# Altium schematic sheet C1804 FreqCounter - REF Clock & Freq (REV04).SchDoc
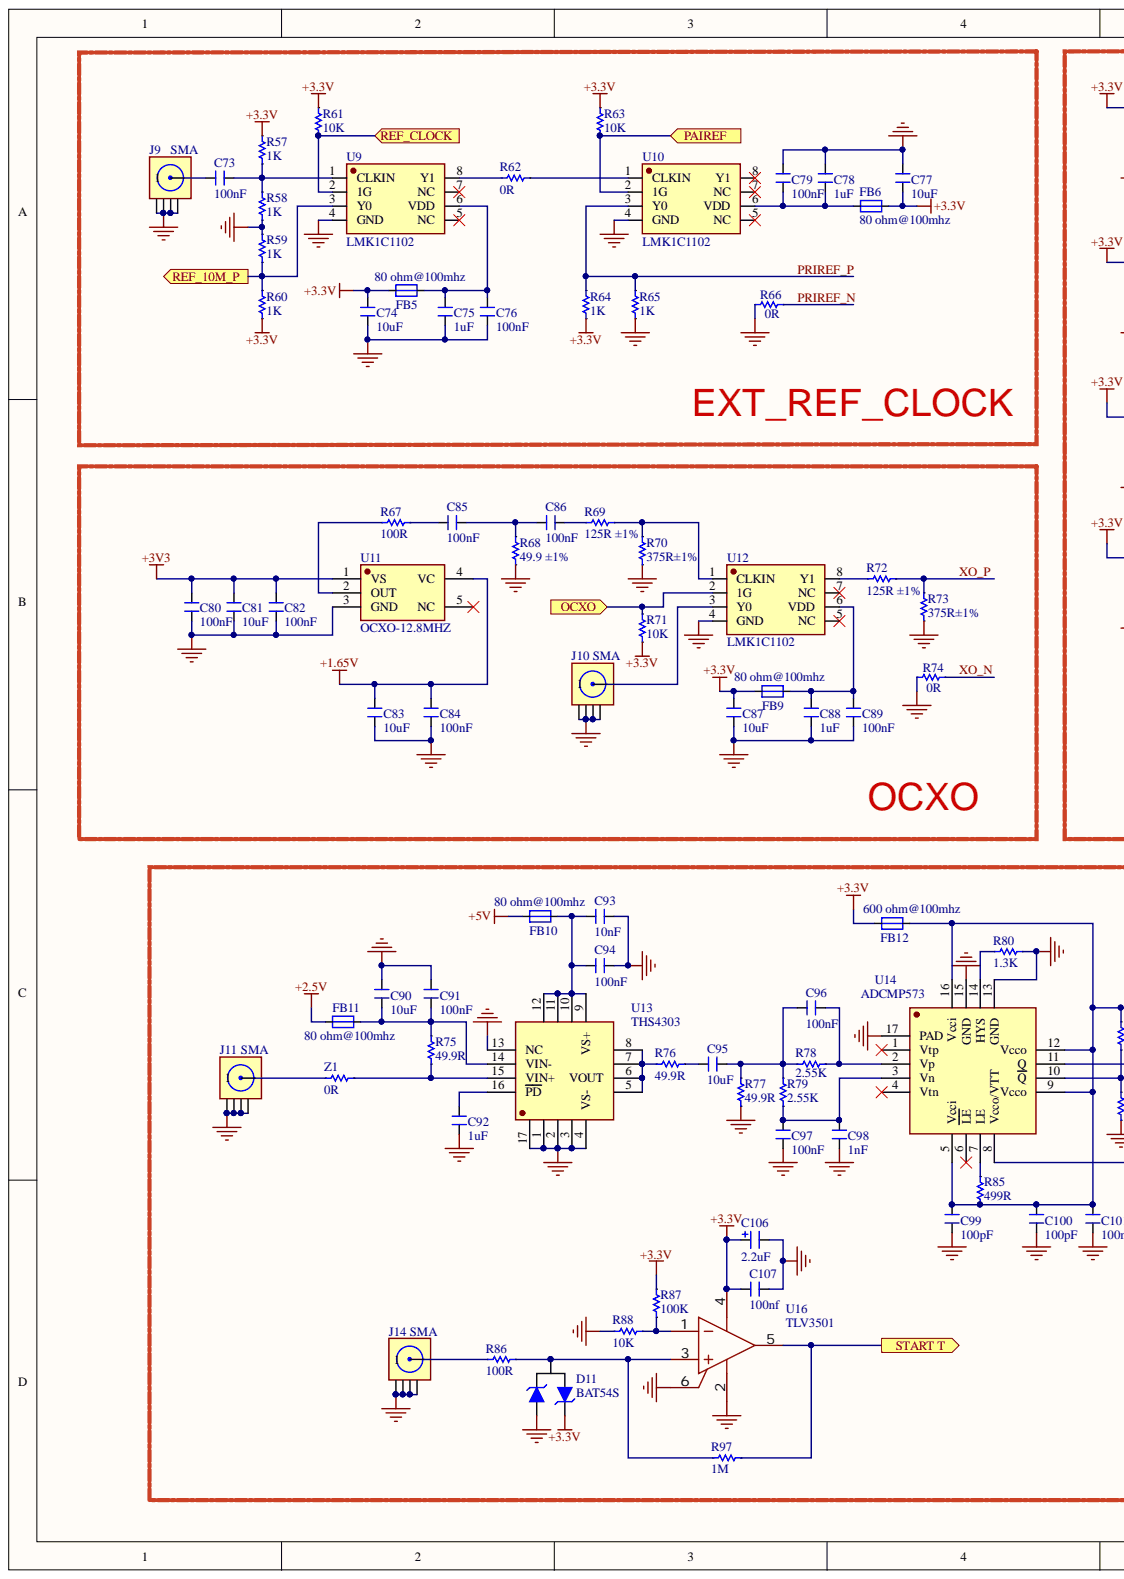
[diagram p0: C1804 FreqCounter - REF Clock & Freq (REV04).SchDoc, left]
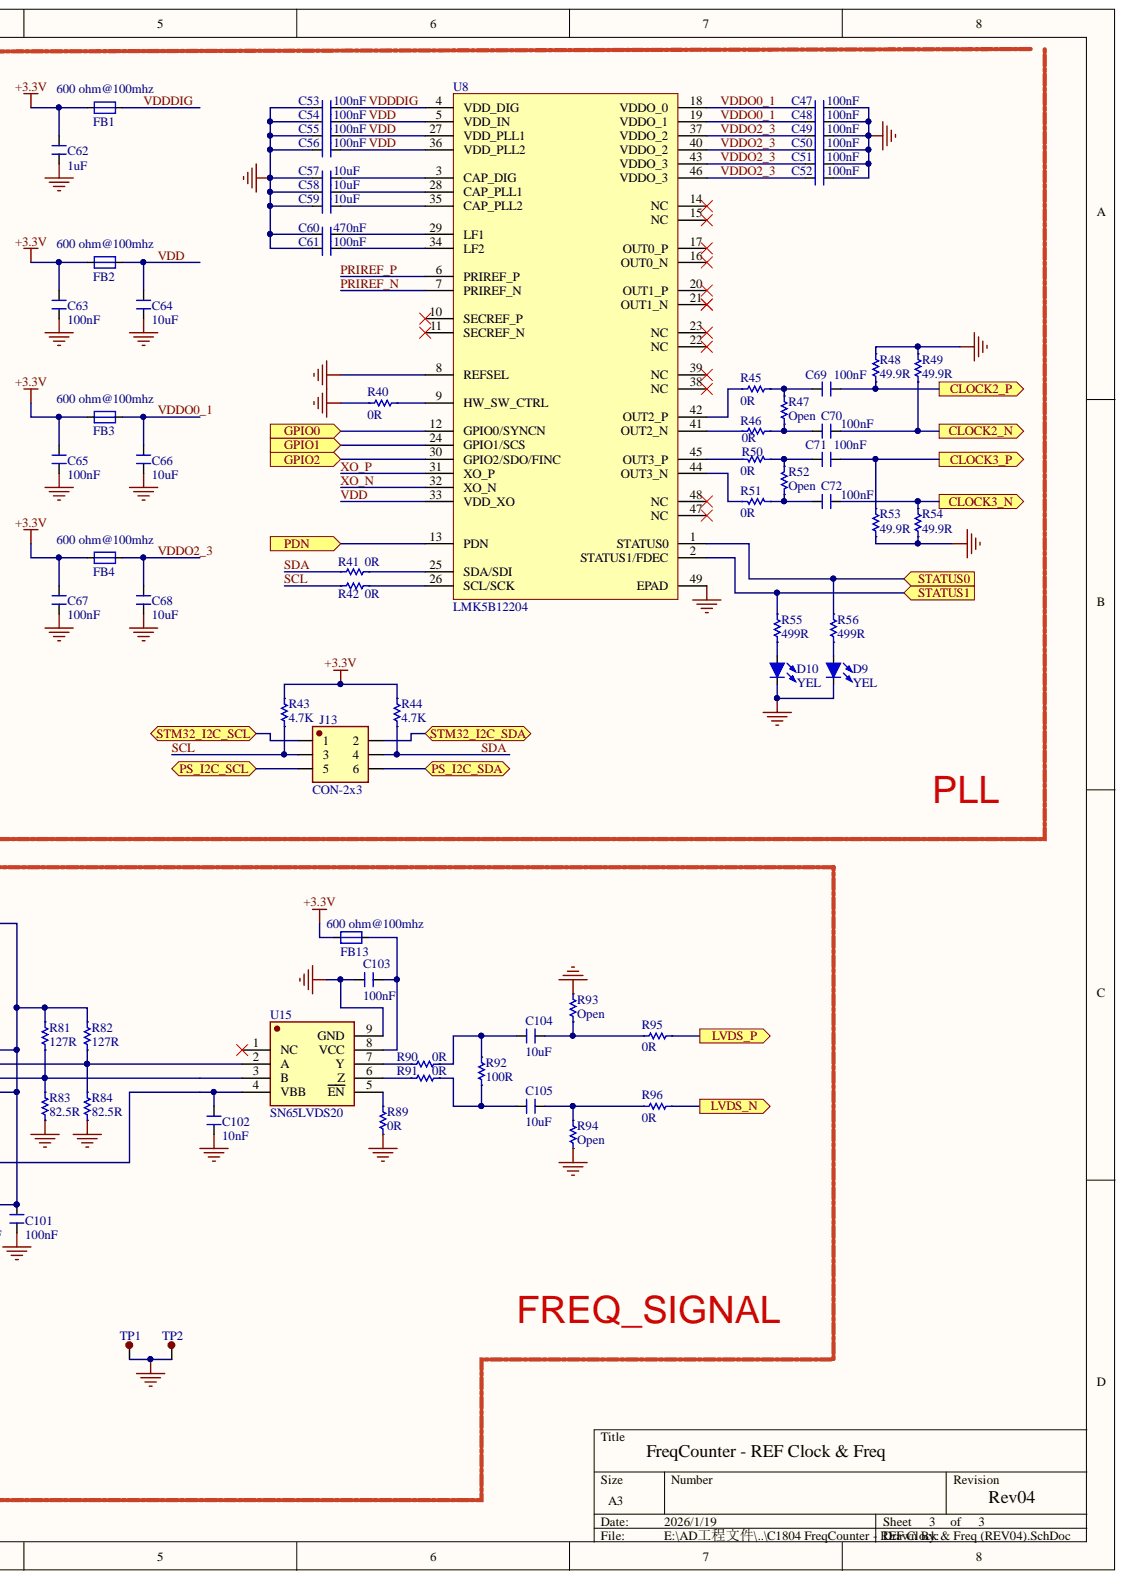
[diagram p1: C1804 FreqCounter - REF Clock & Freq (REV04).SchDoc, right]

## Parts
C47  100nF  [C-0603]
C48  100nF  [C-0603]
C49  100nF  [C-0402]
C50  100nF  [C-0402]
C51  100nF  [C-0402]
C52  100nF  [C-0402]
C53  100nF  [C-0603]
C54  100nF  [C-0603]
C55  100nF  [C-0603]
C56  100nF  [C-0603]
C57  10uF  [C-0603]
C58  10uF  [C-0603]
C59  10uF  [C-0603]
C60  470nF  [C-0603]
C61  100nF  [C-0603]
C62  1uF  [C-0603]
C63  100nF  [C-0603]
C64  10uF  [C-0603]
C65  100nF  [C-0603]
C66  10uF  [C-0603]
C67  100nF  [C-0603]
C68  10uF  [C-0402]
C69  100nF  [C-0603]
C70  100nF  [C-0603]
C71  100nF  [C-0603]
C72  100nF  [C-0603]
C73  100nF  [C-0603]
C74  10uF  [C-0603]
C75  1uF  [C-0603]
C76  100nF  [C-0603]
C77  10uF  [C-0603]
C78  1uF  [C-0603]
C79  100nF  [C-0603]
C80  100nF  [C-0603]
C81  10uF  [C-0805]
C82  100nF  [C-0603]
C83  10uF  [C-0805]
C84  100nF  [C-0603]
C85  100nF  [C-0603]
C86  100nF  [C-0603]
C87  10uF  [C-0603]
C88  1uF  [C-0603]
C89  100nF  [C-0603]
C90  10uF  [C-0603]
C91  100nF  [C-0603]
C92  1uF  [C-0603]
C93  10nF  [C-0603]
C94  100nF  [C-0603]
C95  10uF  [C-0603]
C96  100nF  [C-0603]
C97  100nF  [C-0603]
C98  1nF  [C-0603]
C99  100pF  [C-0603]
C100  100pF  [C-0603]
C101  100nF  [C-0603]
C102  10nF  [C-0603]
C103  100nF  [C-0603]
C104  10uF  [C-0603]
C105  10uF  [C-0603]
C106  2.2uF  [CASE-A (3216)]
C107  100nf  [C-0603]
D9  YEL  [LED-0805]
D10  YEL  [LED-0805]
D11  BAT54S  [SOT-23 (1,2,3)]
FB1  600 ohm@100mhz  [R-0603]
FB2  600 ohm@100mhz  [R-0603]
FB3  600 ohm@100mhz  [R-0603]
FB4  600 ohm@100mhz  [R-0603]
FB5  80 ohm@100mhz  [R-0805]
FB6  80 ohm@100mhz  [R-0805]
FB9  80 ohm@100mhz  [R-0805]
FB10  80 ohm@100mhz  [R-0805]
FB11  80 ohm@100mhz  [R-0805]
FB12  600 ohm@100mhz  [R-0603]
FB13  600 ohm@100mhz  [R-0603]
J9  SMA  [SMA-90DEG]
J10  SMA  [SMA-90DEG]
J11  SMA  [SMA-90DEG]
J13  CON-2x3  [DIP-2x3 (P=2.54MM)]
J14  SMA  [SMA-90DEG]
R40  0R  [R-0603]
R41  0R  [R-0603]
R42  0R  [R-0603]
R43  4.7K  [R-0603]
R44  4.7K  [R-0603]
R45  0R  [R-0603]
R46  0R  [R-0603]
R47  Open  [R-0603]
R48  49.9R  [R-0603]
R49  49.9R  [R-0603]
R50  0R  [R-0603]
R51  0R  [R-0603]
R52  Open  [R-0603]
R53  49.9R  [R-0603]
R54  49.9R  [R-0603]
R55  499R  [R-0603]
R56  499R  [R-0603]
R57  1K  [R-0603]
R58  1K  [R-0603]
R59  1K  [R-0603]
R60  1K  [R-0603]
R61  10K  [R-0603]
R62  0R  [R-0603]
R63  10K  [R-0603]
R64  1K  [R-0603]
R65  1K  [R-0603]
R66  0R  [R-0603]
R67  100R  [R-0603]
R68  49.9 ±1%  [R-0603]
R69  125R ±1%  [R-0603]
R70  375R±1%  [R-0603]
R71  10K  [R-0603]
R72  125R ±1%  [R-0603]
R73  375R±1%  [R-0603]
R74  0R  [R-0603]
R75  49.9R  [R-0603]
R76  49.9R  [R-0603]
R77  49.9R  [R-0603]
R78  2.55K  [R-0603]
R79  2.55K  [R-0603]
R80  1.3K  [R-0603]
R81  127R  [R-0603]
R82  127R  [R-0603]
R83  82.5R  [R-0603]
R84  82.5R  [R-0603]
R85  499R  [R-0603]
R86  100R  [R-0603]
R87  100K  [R-0603]
R88  10K  [R-0603]
R89  0R  [R-0603]
R90  0R  [R-0603]
R91  0R  [R-0603]
R92  100R  [R-0603]
R93  Open  [R-0603]
R94  Open  [R-0603]
R95  0R  [R-0603]
R96  0R  [R-0603]
R97  1M  [R-0603]
TP1  Test Point  [Test point]  (value not drawn)
TP2  Test Point  [Test point]  (value not drawn)
U8  LMK5B12204  [VQFN-48 (7*7,P=0.5mm)]
U9  LMK1C1102  [WSON-8 (2*2,P=0.5MM)]
U10  LMK1C1102  [WSON-8 (2*2,P=0.5MM)]
U11  OCXO-12.8MHZ  [OCXO-5 (20x20mm)]
U12  LMK1C1102  [WSON-8 (2*2,P=0.5MM)]
U13  THS4303  [QFN-16 (P=0.5mm)]
U14  ADCMP573  [QFN-16 (P=0.5mm)]
U15  SN65LVDS20  [WSON-8-EP (2*2,P=0.5MM)]
U16  TLV3501  [SOT23-6]
Z1  0R  [R-0603]

## Ports
CLOCK2_N, CLOCK2_P, CLOCK3_N, CLOCK3_P, GPIO0, GPIO1, GPIO2, LVDS_N, LVDS_P, OCXO, PAIREF, PDN, PS_I2C_SCL, PS_I2C_SDA, REF_10M_P, REF_CLOCK, START T, STATUS0, STATUS1, STM32_I2C_SCL, STM32_I2C_SDA

## Nets
+1.65V: C83.1 C84.1 U11.4(VC)
+2.5V: FB11.1
+3.3V: C62.1 C63.1 C65.1 C67.1 C74.2 C77.2 C87.2 C106.1 C107.1 D11.2 FB1.1 FB2.1 FB3.1 FB4.1 FB5.1 FB6.1 FB9.1 FB12.1 FB13.2 R43.1 R44.1 R57.2 R60.1 R61.2 R63.2 R64.2 R71.1 R87.2 U16.4
+3V3: C80.1 C81.1 C82.1 U11.1(VS)
+5V: FB10.1
GND: C47.2 C48.2 C49.2 C50.2 C51.2 C52.2 C53.1 C54.1 C55.1 C56.1 C57.1 C58.1 C59.1 C60.1 C61.1 C62.2 C63.2 C64.2 C65.2 C66.2 C67.2 C68.2 C74.1 C75.2 C76.2 C77.1 C78.2 C79.2 C80.2 C81.2 C82.2 C83.2 C84.2 C87.1 C88.2 C89.2 C90.1 C91.1 C92.1 C93.2 C94.2 C97.2 C98.2 C99.2 C100.2 C101.2 C102.2 C103.2 C106.2 C107.2 D9.K D10.K D11.1 J9.2 J9.3 J9.4 J9.5 J10.2 J10.3 J10.4 J10.5 J11.2 J11.3 J11.4 J11.5 J14.2 J14.3 J14.4 J14.5 R40.2 R48.2 R49.2 R53.1 R54.1 R58.1 R59.2 R65.1 R66.1 R68.2 R70.2 R73.2 R74.1 R77.1 R80.2 R83.1 R84.1 R88.1 R89.2 R93.2 R94.1 TP1.1 TP2.1 U8.8(REFSEL) U8.49(EPAD) U9.4(GND) U10.4(GND) U11.3(GND) U12.4(GND) U13.1 U13.2 U13.3 U13.4(VS-) U13.13(NC) U13.17 U14.13(GND) U14.15(GND) U14.17(PAD) U15.9(GND) U16.2 U16.6
CLOCK2_N: C70.1 R49.1 ; port CLOCK2_N
CLOCK2_P: C69.1 R48.1 ; port CLOCK2_P
CLOCK3_N: C72.1 R54.2 ; port CLOCK3_N
CLOCK3_P: C71.1 R53.2 ; port CLOCK3_P
GPIO0: U8.12(GPIO0/SYNCN) ; port GPIO0
GPIO1: U8.24(GPIO1/SCS) ; port GPIO1
GPIO2: U8.30(GPIO2/SDO/FINC) ; port GPIO2
LVDS_N: R96.1 ; port LVDS_N
LVDS_P: R95.1 ; port LVDS_P
OCXO: R71.2 U12.2(1G) ; port OCXO
PAIREF: R63.1 U10.2(1G) ; port PAIREF
PDN: U8.13(PDN) ; port PDN
PRIREF_N: R66.2 U8.7(PRIREF_N)
PRIREF_P: R64.1 R65.2 U8.6(PRIREF_P) U10.3(Y0)
PS_I2C_SCL: J13.5 ; port PS_I2C_SCL
PS_I2C_SDA: J13.6 ; port PS_I2C_SDA
REF_10M_P: R59.1 R60.2 U9.3(Y0) ; port REF_10M_P
REF_CLOCK: R61.1 U9.2(1G) ; port REF_CLOCK
SCL: J13.3 R42.1 R43.2
SDA: J13.4 R41.1 R44.2
START T: R97.2 U16.5 ; port START T
STATUS0: R56.2 U8.1(STATUS0) ; port STATUS0
STATUS1: R55.2 U8.2(STATUS1/FDEC) ; port STATUS1
STM32_I2C_SCL: J13.1 ; port STM32_I2C_SCL
STM32_I2C_SDA: J13.2 ; port STM32_I2C_SDA
VDD: C54.2 C55.2 C56.2 C64.1 FB2.2 U8.5(VDD_IN) U8.27(VDD_PLL1) U8.33(VDD_XO) U8.36(VDD_PLL2)
VDDDIG: C53.2 FB1.2 U8.4(VDD_DIG)
VDDO0_1: C47.1 C48.1 C66.1 FB3.2 U8.18(VDDO_0) U8.19(VDDO_1)
VDDO2_3: C49.1 C50.1 C51.1 C52.1 C68.1 FB4.2 U8.37(VDDO_2) U8.40(VDDO_2) U8.43(VDDO_3) U8.46(VDDO_3)
XO_N: R74.2 U8.32(XO_N)
XO_P: R72.1 R73.1 U8.31(XO_P)
NetC57_2: C57.2 U8.3(CAP_DIG)
NetC58_2: C58.2 U8.28(CAP_PLL1)
NetC59_2: C59.2 U8.35(CAP_PLL2)
NetC60_2: C60.2 U8.29(LF1)
NetC61_2: C61.2 U8.34(LF2)
NetC69_2: C69.2 R45.2 R47.2
NetC70_2: C70.2 R46.2 R47.1
NetC71_2: C71.2 R50.2 R52.1
NetC72_2: C72.2 R51.2 R52.2
NetC73_1: C73.1 J9.1
NetC73_2: C73.2 R57.1 R58.2 U9.1(CLKIN)
NetC75_1: C75.1 C76.1 FB5.2 U9.6(VDD)
NetC78_1: C78.1 C79.1 FB6.2 U10.6(VDD)
NetC85_1: C85.1 R67.1
NetC85_2: C85.2 C86.1 R68.1
NetC86_2: C86.2 R69.2
NetC88_1: C88.1 C89.1 FB9.2 U12.6(VDD)
NetC90_2: C90.2 C91.2 FB11.2 R75.2 U13.14(VIN-)
NetC92_2: C92.2 U13.16(PD)
NetC93_1: C93.1 C94.1 FB10.2 U13.9(VS+) U13.10 U13.11 U13.12
NetC95_1: C95.1 R76.2
NetC95_2: C95.2 C96.1 R77.2 R78.2 R79.2
NetC96_2: C96.2 R78.1 U14.2(Vp)
NetC97_1: C97.1 C98.1 R79.1 U14.3(Vn)
NetC99_1: C99.1 C100.1 C101.1 FB12.2 R81.2 R82.2 R85.1 U14.5(Vcci) U14.9(Vcco) U14.12(Vcco) U14.16(Vcci)
NetC102_1: C102.1 U14.8(Vcco/VTT) U15.4(VBB)
NetC103_1: C103.1 FB13.1 U15.8(VCC)
NetC104_1: C104.1 R90.1 R92.2
NetC104_2: C104.2 R93.1 R95.2
NetC105_1: C105.1 R91.1 R92.1
NetC105_2: C105.2 R94.2 R96.2
NetD9_A: D9.A R56.1
NetD10_A: D10.A R55.1
NetD11_3: D11.3 R86.2 R97.1 U16.3
NetJ10_1: J10.1 U12.3(Y0)
NetJ11_1: J11.1 Z1.1
NetJ14_1: J14.1 R86.1
NetR40_1: R40.1 U8.9(HW_SW_CTRL)
NetR41_2: R41.2 U8.25(SDA/SDI)
NetR42_2: R42.2 U8.26(SCL/SCK)
NetR45_1: R45.1 U8.42(OUT2_P)
NetR46_1: R46.1 U8.41(OUT2_N)
NetR50_1: R50.1 U8.45(OUT3_P)
NetR51_1: R51.1 U8.44(OUT3_N)
NetR62_1: R62.1 U10.1(CLKIN)
NetR62_2: R62.2 U9.8(Y1)
NetR67_2: R67.2 U11.2(OUT)
NetR69_1: R69.1 R70.1 U12.1(CLKIN)
NetR72_2: R72.2 U12.8(Y1)
NetR75_1: R75.1 U13.15(VIN+) Z1.2
NetR76_1: R76.1 U13.5 U13.6(VOUT) U13.7 U13.8
NetR80_1: R80.1 U14.14(HYS)
NetR81_1: R81.1 R83.2 U14.10(Q) U15.3(B)
NetR82_1: R82.1 R84.2 U14.11(Q) U15.2(A)
NetR85_2: R85.2 U14.7(LE)
NetR87_1: R87.1 R88.2 U16.1
NetR89_1: R89.1 U15.5(EN)
NetR90_2: R90.2 U15.7(Y)
NetR91_2: R91.2 U15.6(Z)

Not connected: U8 10(SECREF_P) 11(SECREF_N) 14(NC) 15(NC) 16(OUT0_N) 17(OUT0_P) 20(OUT1_P) 21(OUT1_N) 22(NC) 23(NC) 38(NC) 39(NC) 47(NC) 48(NC); U9 5(NC) 7(NC); U10 5(NC) 7(NC) 8(Y1); U11 5(NC); U12 5(NC) 7(NC); U14 1(Vtp) 4(Vtn) 6(LE); U15 1(NC)

## Library data of the parts
C47-C105 C107 = CAP: Component Kind: Standard; Library Name: HW SCH Lib.SCHLIB
C106 = Cap Pol: Polarized Capacitor (Surface Mount); Value: 10uF; Component Kind: Standard; LatestRevisionDate: 17-Jul-2002; LatestRevisionNote: Re-released for DXP Platform.; Library Name: HW SCH Lib.SCHLIB; PackageReference: C0805; Published: 8-Jun-2000; Publisher: Altium Limited
D9 D10 = Diode LED: Typical RED, GREEN, YELLOW, AMBER GaAs LED; Component Kind: Standard; LatestRevisionDate: 17-Jul-2002; LatestRevisionNote: Re-released for DXP Platform.; Library Name: HW SCH Lib.SCHLIB; PackageDocument: Feb-2002; PackageReference: 3.2X1.6X1.1; Published: 8-Jun-2000; Publisher: Altium Limited
D11 = BAT54S: Schottky; High Current Capability; Component Kind: Standard; Library Name: HW SCH Lib.SCHLIB
FB1-FB6 FB9-FB13 = FB1: Component Kind: Standard; Library Name: HW SCH Lib.SCHLIB
J9-J11 J14 = SMA: SMA Connector; Component Kind: Standard; Library Name: HW SCH Lib.SCHLIB
J13 = CON-2x3P: Component Kind: Standard; Library Name: HW SCH Lib.SCHLIB
R40-R97 Z1 = RES: : 10K; Component Kind: Standard; Library Name: HW SCH Lib.SCHLIB
U8 = LMK5B12204: clock defibrillator; Component Kind: Standard; Library Name: HW SCH Lib.SCHLIB
U9 U10 U12 = LMK1C1102: CH2 Clock buffer; Component Kind: Standard; Library Name: HW SCH Lib.SCHLIB
U11 = OCXO: Component Kind: Standard; Library Name: HW SCH Lib.SCHLIB
U14 = ADCMP573: Single-Supply Sige Comparators; Component Kind: Standard; Library Name: HW SCH Lib.SCHLIB
U15 = SN65LVDS20: LVDS microchip; Component Kind: Standard; Library Name: HW SCH Lib.SCHLIB
U16 = TLV3501: push-pull output comparators; Component Kind: Standard; Library Name: HW SCH Lib.SCHLIB
TP1 TP2 = Test Point: Component Kind: Standard; Library Name: HW SCH Lib.SCHLIB
U13 = THS4303: Component Kind: Standard; Library Name: HW SCH Lib.SCHLIB
